# Altium schematic sheet micromouse_v1.SchDoc(micromouse_v1)
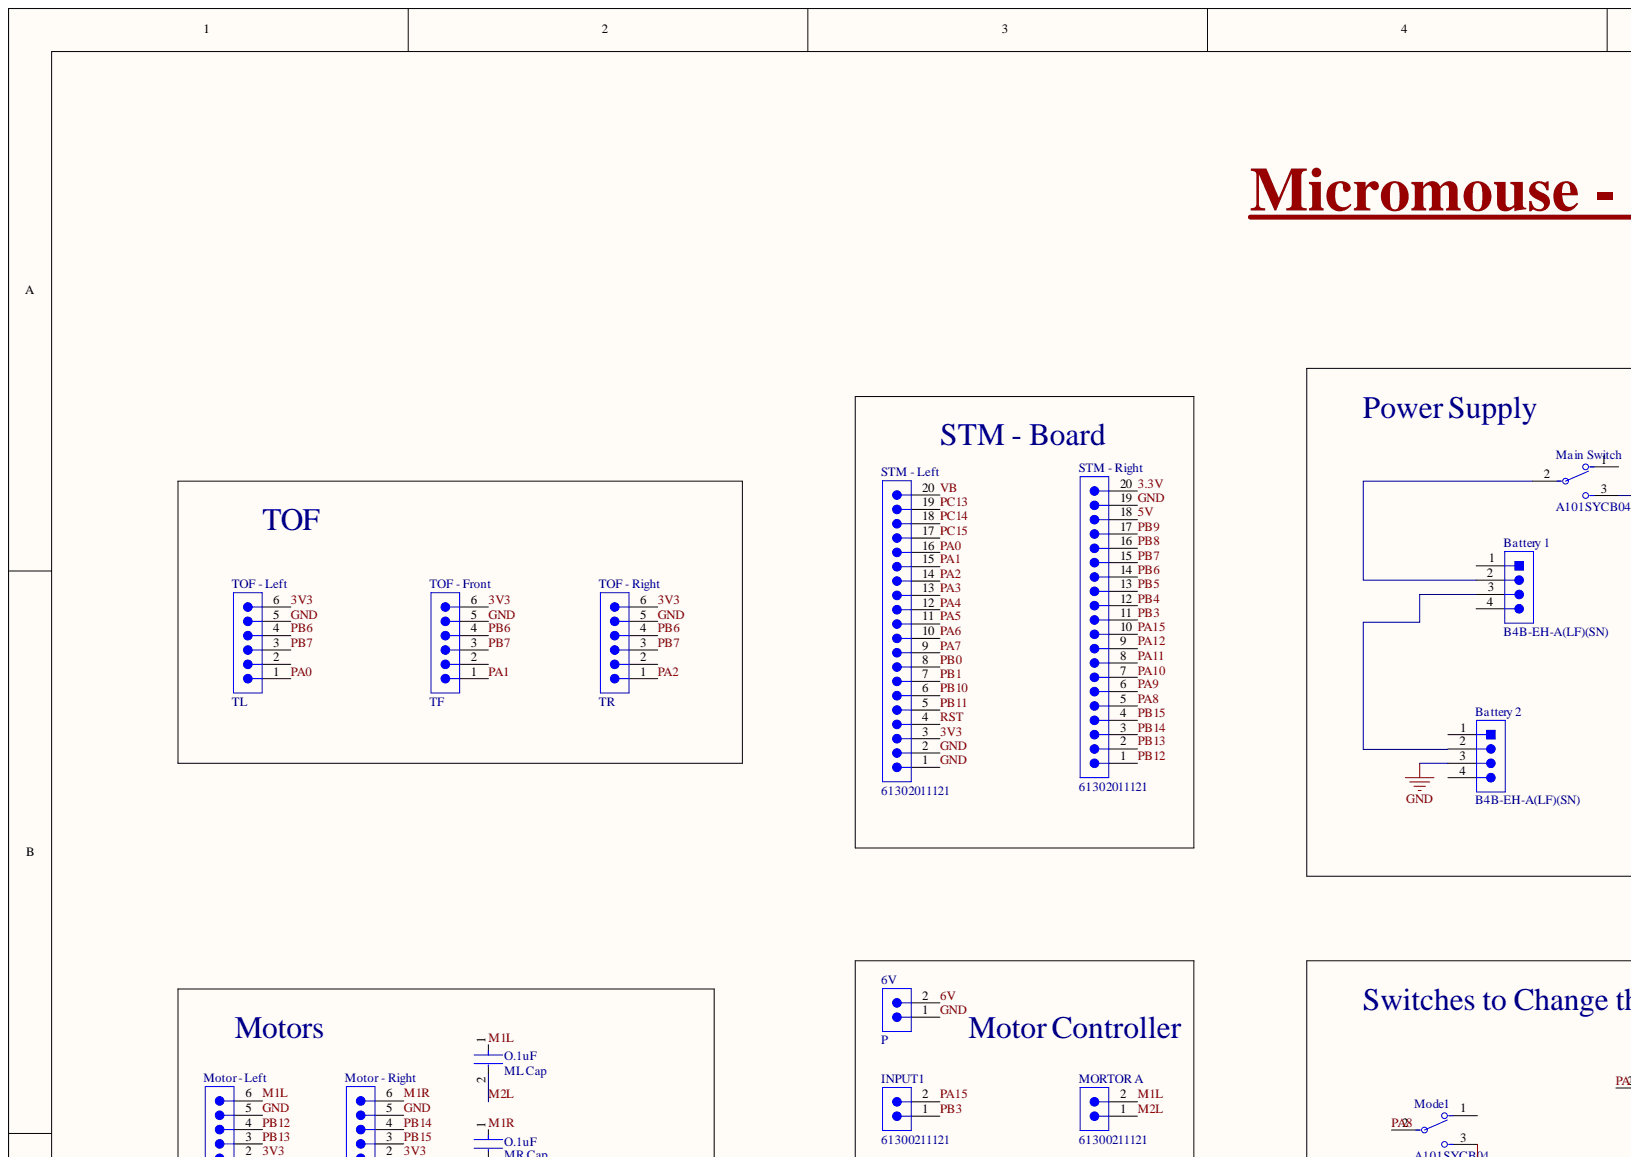
[diagram p0: micromouse_v1.SchDoc, top left]
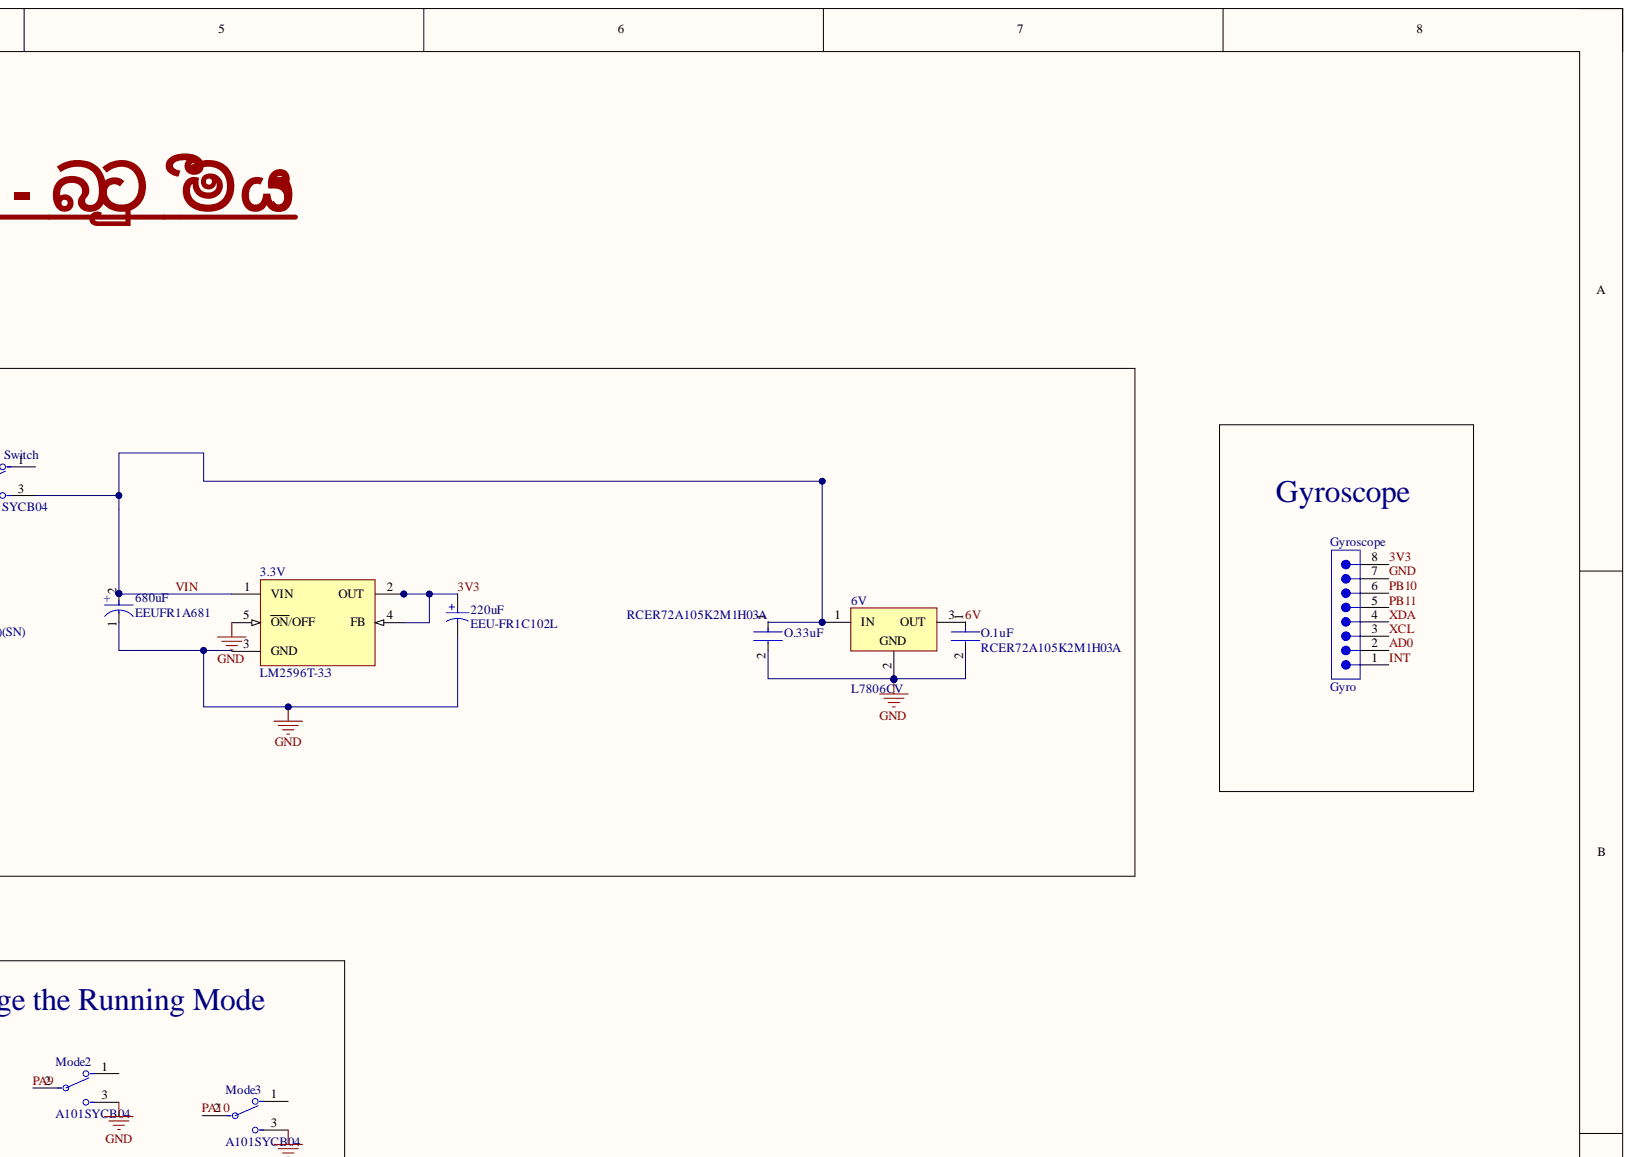
[diagram p1: micromouse_v1.SchDoc, top right]
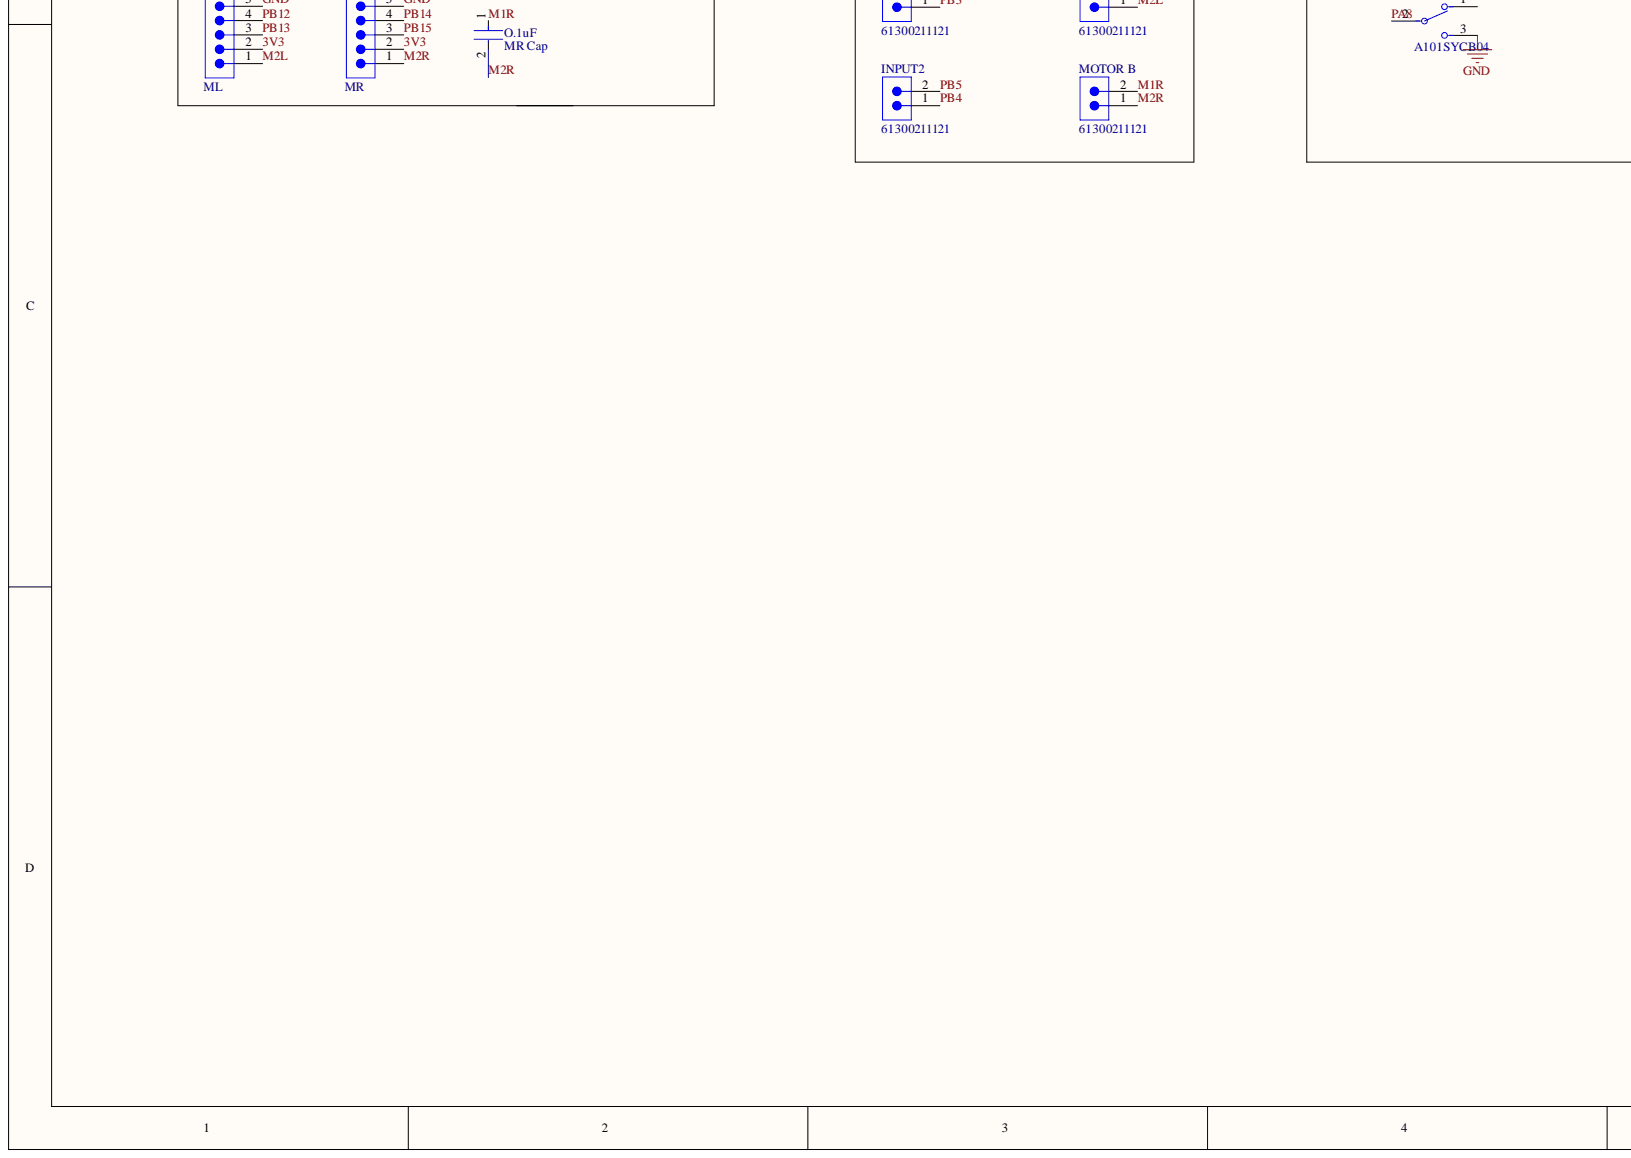
[diagram p2: micromouse_v1.SchDoc, bottom left]
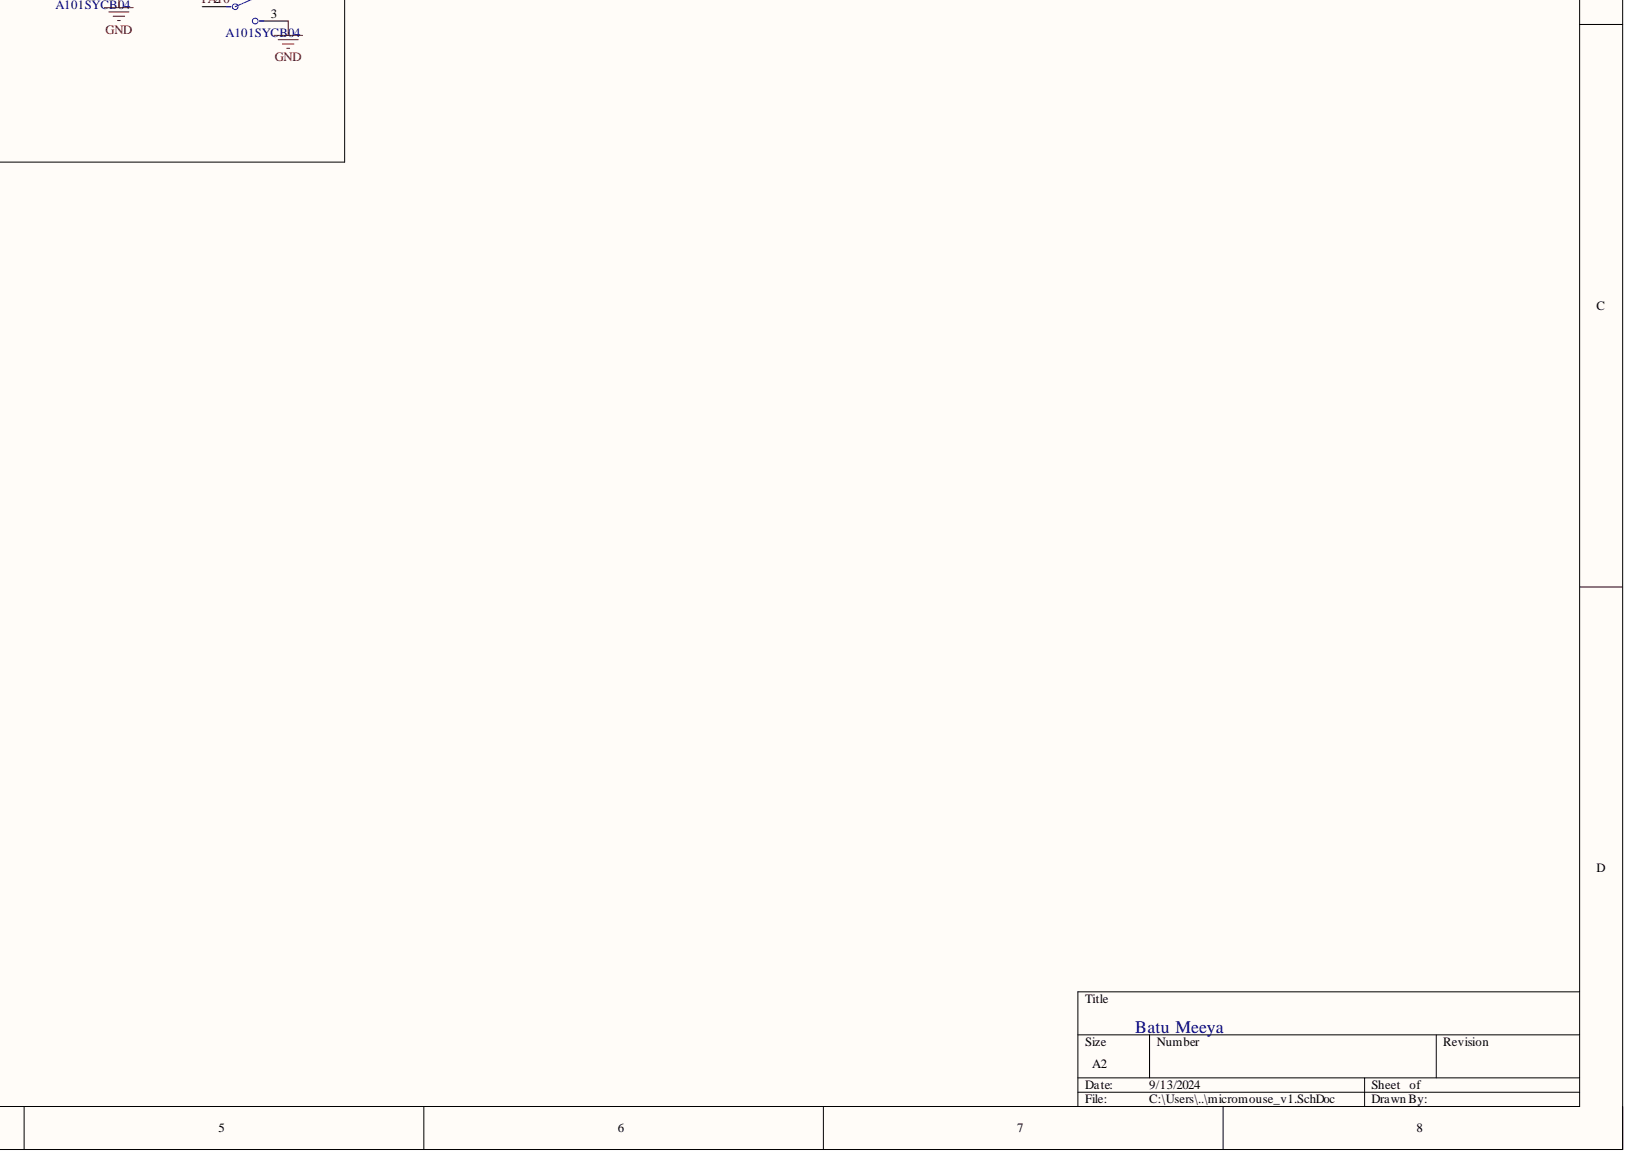
[diagram p3: micromouse_v1.SchDoc, bottom right]

## Parts
3.3V  LM2596T-3.3  [T05D]
6V  L7806CV  [TO220]
6V  P  [61300211121]
220uF  EEU-FR1C102L  [PNSC-FR-A-8X20-2_V]
680uF  EEUFR1A681  Capacitance: 680uF  [FP-RAD-TH-D_8_0_5-L_11_5-MFG]
Battery_1  B4B-EH-A(LF)(SN)  [JST-B4B-EH-A_V]
Battery_2  B4B-EH-A(LF)(SN)  [JST-B4B-EH-A_V]
Gyroscope  Gyro  [61300811121]
INPUT1  61300211121  [61300211121]
INPUT2  61300211121  [61300211121]
Main_Switch  A101SYCB04  [FP-A101SYCB04-MFG]
Mode1  A101SYCB04  [FP-A101SYCB04-MFG]
Mode2  A101SYCB04  [FP-A101SYCB04-MFG]
Mode3  A101SYCB04  [FP-A101SYCB04-MFG]
MORTOR_A  61300211121  [61300211121]
Motor_-_Left  ML  [61300611121]
Motor_-_Right  MR  [61300611121]
MOTOR_B  61300211121  [61300211121]
O.1uF  MR Cap  [FP-RCE2K1-MFG]
O.1uF  ML Cap  [FP-RCE2K1-MFG]
O.1uF  RCER72A105K2M1H03A  [FP-RCE2K1-MFG]
O.33uF  RCER72A105K2M1H03A  [FP-RCE2K1-MFG]
STM_-_Left  61302011121  [61302011121]
STM_-_Right  61302011121  [61302011121]
TOF_-_Front  TF  [61300611121]
TOF_-_Left  TL  [61300611121]
TOF_-_Right  TR  [61300611121]

## Nets
3.3V: STM_-_Right.20
3V3: 3.3V.2(OUT) 3.3V.4(FB) 220uF.1 Gyroscope.8 Motor_-_Left.2 Motor_-_Right.2 STM_-_Left.3 TOF_-_Front.6 TOF_-_Left.6 TOF_-_Right.6
5V: STM_-_Right.18
6V: 6V.2 6V.3
AD0: Gyroscope.2
GND: 3.3V.5(ON/OFF) 6V.1 6V.2 220uF.2 680uF.1 Battery_2.3 Gyroscope.7 Mode1.3 Mode2.3 Mode3.3 Motor_-_Left.5 Motor_-_Right.5 O.1uF.2 O.33uF.2 STM_-_Left.1 STM_-_Left.2 STM_-_Right.19 TOF_-_Front.5 TOF_-_Left.5 TOF_-_Right.5
INT: Gyroscope.1
M1L: MORTOR_A.2 Motor_-_Left.6 O.1uF.1
M1R: Motor_-_Right.6 MOTOR_B.2 O.1uF.1
M2L: MORTOR_A.1 Motor_-_Left.1 O.1uF.2
M2R: Motor_-_Right.1 MOTOR_B.1 O.1uF.2
PA0: STM_-_Left.16 TOF_-_Left.1
PA1: STM_-_Left.15 TOF_-_Front.1
PA2: STM_-_Left.14 TOF_-_Right.1
PA3: STM_-_Left.13
PA4: STM_-_Left.12
PA5: STM_-_Left.11
PA6: STM_-_Left.10
PA7: STM_-_Left.9
PA8: Mode1.2 STM_-_Right.5
PA9: Mode2.2 STM_-_Right.6
PA10: Mode3.2 STM_-_Right.7
PA11: STM_-_Right.8
PA12: STM_-_Right.9
PA15: INPUT1.2 STM_-_Right.10
PB0: STM_-_Left.8
PB1: STM_-_Left.7
PB3: INPUT1.1 STM_-_Right.11
PB4: INPUT2.1 STM_-_Right.12
PB5: INPUT2.2 STM_-_Right.13
PB6: STM_-_Right.14 TOF_-_Front.4 TOF_-_Left.4 TOF_-_Right.4
PB7: STM_-_Right.15 TOF_-_Front.3 TOF_-_Left.3 TOF_-_Right.3
PB8: STM_-_Right.16
PB9: STM_-_Right.17
PB10: Gyroscope.6 STM_-_Left.6
PB11: Gyroscope.5 STM_-_Left.5
PB12: Motor_-_Left.4 STM_-_Right.1
PB13: Motor_-_Left.3 STM_-_Right.2
PB14: Motor_-_Right.4 STM_-_Right.3
PB15: Motor_-_Right.3 STM_-_Right.4
PC13: STM_-_Left.19
PC14: STM_-_Left.18
PC15: STM_-_Left.17
RST: STM_-_Left.4
VB: STM_-_Left.20
VIN: 3.3V.1(VIN) 6V.1 680uF.2 Main_Switch.3 O.33uF.1
XCL: Gyroscope.3
XDA: Gyroscope.4
NetBattery 1_2: Battery_1.2 Main_Switch.2

Not connected: 3.3V 3(GND); Battery_1 1 3 4; Battery_2 1 2 4; Main_Switch 1; Mode1 1; Mode2 1; Mode3 1; TOF_-_Front 2; TOF_-_Left 2; TOF_-_Right 2

## Library data of the parts
3.3V = CMP-0069-01929-2: SIMPLE SWITCHER® Power Converter 150 KHz 3A Step-Down Voltage Regulator, 5-pin TO-220; Manufacturer: National Semiconductor; PackageTopMarking: NSUZXYTTE#*LM2596T*-3.3 P+; PowerWise: N; Component Kind: Standard; ComponentLink1Description: LM2596 Datasheet; ComponentLink1URL: http://www.national.com/ds/LM/LM2596.pdf; ComponentLink2Description: LM2596 Web Page; ComponentLink2URL: http://www.national.com/pf/LM/LM2596.html; ComponentLink3Description: T05D Package Page; ComponentLink3URL: http://www.national.com/packaging/folders/t05d.html; CompType: Switcher; DatasheetVersion: May-2002; Library Name: Altium Content Vault; PackageDescription: TO-220, Molded, Stagger Bend, 5 Lead; PackageReference: T05D; PackageVersion: revA, Oct-1989; PinCount: 5; RevisionNote: Component URLs added; RoHS: N
6V = CMP-0244-00495-1: Positive Voltage Regulator, 6V, 3-Pin TO-220; Component Kind: Standard; ComponentLink1Description: Manufacturer URL; ComponentLink1URL: http://www.st.com; ComponentLink2Description: Datasheet; ComponentLink2URL: http://www.st.com/internet/com/TECHNICAL_RESOURCES/TECHNICAL_LITERATURE/DATASHEET/CD00000444.pdf; ComponentLink3Description: Package Specification; ComponentLink3URL: http://www.st.com/internet/com/TECHNICAL_RESOURCES/TECHNICAL_LITERATURE/PACKAGE_INFORMATION/CD00004649.pdf; DatasheetVersion: 16/11/2011; Library Name: Altium Content Vault; PackageDescription: TO, Thru-Hole, Vertical, Heatsink Mounted; 3 In-Line Leads; Pitch 2.54 mm; PackageReference: TO220; PackageVersion: ver3.0
6V INPUT1 INPUT2 MORTOR_A MOTOR_B = CMP-1712-00002-6: NexarPartId: 20081794; Connector Type: Male Pin; Contact Resistance: 20mΩ; Current Rating: 3A; Gender: Male; Number of Contacts: 2; Operating Supply Voltage: 250V; Pitch: 2.54mm; Color: Black; Component Kind: Standard; Contact Gender: Male; Contact Material: Copper; Contact Mating Length: 5.9944mm; Contact Plating: Gold; Height: 8.94mm; Housing Material: PBT; Lead Free: Lead Free; Lead Pitch: 2.54mm; Library Name: Altium Content Vault; Max Operating Temperature: 125°C; Min Operating Temperature: -40°C; Mount: Through Hole; Number of Pins: 2; Number of Positions: 2; Number of Rows: 1; Orientation: Vertical; Packaging: Bulk; REACH SVHC: No SVHC; RoHS: Compliant; Termination: Through Hole; Voltage Rating: 250V
220uF = CMP-0744-00002-1: Aluminum Electrolytic Capacitor, 1000 uF +/- 20%, 16 V, -40 to 105 degC, 2-Pin THD, Dia 8 mm, Body Length 22 mm, RoHS; Manufacturer: Panasonic; Capacitance: 1000 uF; Rated Ripple Current: 1560 mA; Rated Voltage: 16 V; Resistance: 0.03 Ohm; Tolerance: +/- 20%; Component Kind: Standard; ComponentLink1Description: Manufacturer URL; ComponentLink1URL: http://www.panasonic.com/industrial/electronic-components/; ComponentLink2Description: Datasheet; ComponentLink2URL: http://www.panasonic.com/industrial/includes/pdf/EEU-FR_DataSheet.pdf; DatasheetVersion: Apr-10; Library Name: Altium Content Vault; Mounting Technology: Through Hole; PackageDescription: 2-Pin Radial Lead, Dia 8 mm, Body Length 22 mm, Pin Spacing 3.5 mm; PackageReference: FR-A-8X20-2; PartNumber: EEU-FR1C102L; RoHS: TRUE; Temperature Range: -40 to 105 degC
680uF = CMP-05427-000255-1: Aluminum Electrolytic Capacitors (Radial Lead Type) 680uF ±20% 10V; ESR (Equivalent Series Resistance): 56mR; Lead Diameter: 0.6mm; Lead/Base Style: Straight; Life (Hours): 6000Hour; Ripple Current: 950mA; Case/Package: Radial; Component Kind: Standard; Construction: RADIAL; Contact Plating: Tin; Diameter: 8mm; Height: 11.5mm; Height - Seated (Max): 0.512inch; Impedance: 56mR; Lead Free: Lead Free; Lead Pitch: 3.5mm; Length: 11.5mm; Library Name: Altium Content Vault; Max Operating Temperature: 105°C; Min Operating Temperature: -40°C; Mount: Through Hole; Number of Pins: 2; Package Quantity: 200; Packaging: Bulk; Radiation Hardening: No; REACH SVHC: No SVHC; Ripple Current (AC): 950mA; RoHS Compliant: Yes; Series: FR; Termination: Radial; Tolerance: 20%; Voltage: 10V; Voltage Rating: 10V; Voltage Rating (DC): 10V
Battery_1 Battery_2 = CMP-2000-05904-1: Male Header, Pitch 2.5 mm, 1 x 4 Position, Height 6 mm, Tail Length 3.2 mm, -25 to 85 degC, RoHS, Bulk; Manufacturer: JST; No of Positions: 4; Pitch: 2.5mm; Style/Orientation: StraightHeader/Plug; Component Kind: Standard; Datasheet URL: http://www.jst-mfg.com/product/pdf/eng/eEH.pdf; Datasheet Version: 12/2012; Library Name: Altium Content Vault; Manufacturer URL: http://www.jst.com/home2.html; Mounting Technology: SurfaceMount; Package Description: Male Header, Pitch 2.5 mm, 1 x 4 Position, Height 6 mm, Tail Length 3.2 mm; Package Reference: B4B-EH-A; Termination: Crimp; Type: ShroudedHeader
Gyroscope = CMP-1502-01076-3: NexarPartId: 32855533; Connector Type: Male Pin; Contact Resistance: 20mΩ; Current Rating: 3A; Gender: Male; Number of Contacts: 8; Operating Supply Voltage: 250V; Pitch: 2.54mm; Color: Black; Component Kind: Standard; Contact Material: Copper; Contact Mating Length: 5.9944mm; Contact Plating: Gold; Height: 8.54mm; Lead Pitch: 2.54mm; Library Name: Altium Content Vault; Max Operating Temperature: 105°C; Min Operating Temperature: -40°C; Mount: Through Hole; Number of Pins: 8; Number of Positions: 8; Number of Rows: 1; Orientation: Vertical; Packaging: Bulk; REACH SVHC: Unknown; RoHS: Compliant; Termination: Solder; Voltage Rating: 250V
Main_Switch Mode1-Mode3 = CMP-03408-000017-1: SWITCH TOGGLE SPDT 0.4VA 20V; Actuator Color: Chrome; Actuator Length: 10.41mm; Actuator Material: Phenolic; Actuator Type: Standard Round; Contact Current Rating: 400mA; Contact Resistance: 10mR; Electrical Life: 6000Cycles; Illumination Type: Non-Illuminated; Insulation Resistance: 30MR; Number of Poles: 1; Number of Positions: 2; Power Rating: 400mW; Switch Function: On-On; Component Kind: Standard; Contact Material: Copper; Contact Plating: Gold; Depth: 6.86mm; ELV: Compliant; Flammability Rating: UL94 V-1; Lead Free: Lead Free; Library Name: Altium Content Vault; Max Operating Temperature: 85°C; Max Voltage Rating (AC): 20V; Max Voltage Rating (DC): 20V; Min Operating Temperature: -30°C; Mount: Panel; Orientation: Straight; Package Quantity: 100; Packaging: Bulk; Radiation Hardening: No; REACH SVHC: No SVHC; RoHS Compliant: Yes; Series: Gemini A; Termination: PC Pins; Throw Configuration: SPDT; Voltage Rating (AC): 20V; Voltage Rating (DC): 20V
Motor_-_Left Motor_-_Right TOF_-_Front TOF_-_Left TOF_-_Right = CMP-1502-01070-3: NexarPartId: 20084590; Connector Type: Male Pin; Contact Resistance: 20mΩ; Current Rating: 3A; Gender: Male; Number of Contacts: 6; Operating Supply Voltage: 250V; Pitch: 2.54mm; Color: Black; Component Kind: Standard; Contact Gender: Male; Contact Material: Copper; Contact Mating Length: 5.9944mm; Contact Plating: Gold; Height: 8.89mm; Housing Material: PBT; Lead Free: Lead Free; Lead Pitch: 2.54mm; Library Name: Altium Content Vault; Max Operating Temperature: 105°C; Min Operating Temperature: -40°C; Mount: Through Hole; Number of Pins: 6; Number of Positions: 6; Number of Rows: 1; Orientation: Vertical; Packaging: Bulk; REACH SVHC: Unknown; RoHS: Compliant; Termination: Through Hole; Voltage Rating: 250V
O.1uF O.1uF O.1uF O.33uF = CMP-06035-007361-1: Cap Ceramic Monolithic 1uF 100V 10% Thru-Hole Bulk; Dielectric: X7R; Lead Diameter: 0.5mm; Lead/Base Style: Formed Leads; Capacitance: 1uF; Case/Package: Radial; Component Kind: Standard; Height: 4mm; Height - Seated (Max): 0.157inch; Lead Free: Lead Free; Lead Pitch: 0.197inch; Length: 0.217inch; Library Name: Altium Content Vault; Max Operating Temperature: 125°C; Min Operating Temperature: -55°C; Mount: Through Hole; Package Quantity: 2000; Packaging: Tape and Reel; Ratings: AEC-Q200; Resistor Type: Ceramic; Termination: Radial; Tolerance: 10%; Voltage Rating: 100V; Voltage Rating (DC): 100V; Width: 0.124inch
STM_-_Left STM_-_Right = CMP-1502-01102-3: NexarPartId: 32855554; Connector Type: Male Pin; Contact Resistance: 20mΩ; Current Rating: 3A; Gender: Male; Number of Contacts: 20; Operating Supply Voltage: 250V; Pitch: 2.54mm; Color: Black; Component Kind: Standard; Contact Material: Copper; Contact Mating Length: 5.9944mm; Contact Plating: Gold; Lead Pitch: 2.54mm; Library Name: Altium Content Vault; Mount: Through Hole; Number of Pins: 20; Number of Positions: 20; Number of Rows: 1; Orientation: Vertical; Packaging: Bulk; REACH SVHC: Unknown; RoHS: Compliant; Termination: Solder
